# Altium schematic sheet p14_WIFI_SDCARD.SchDoc
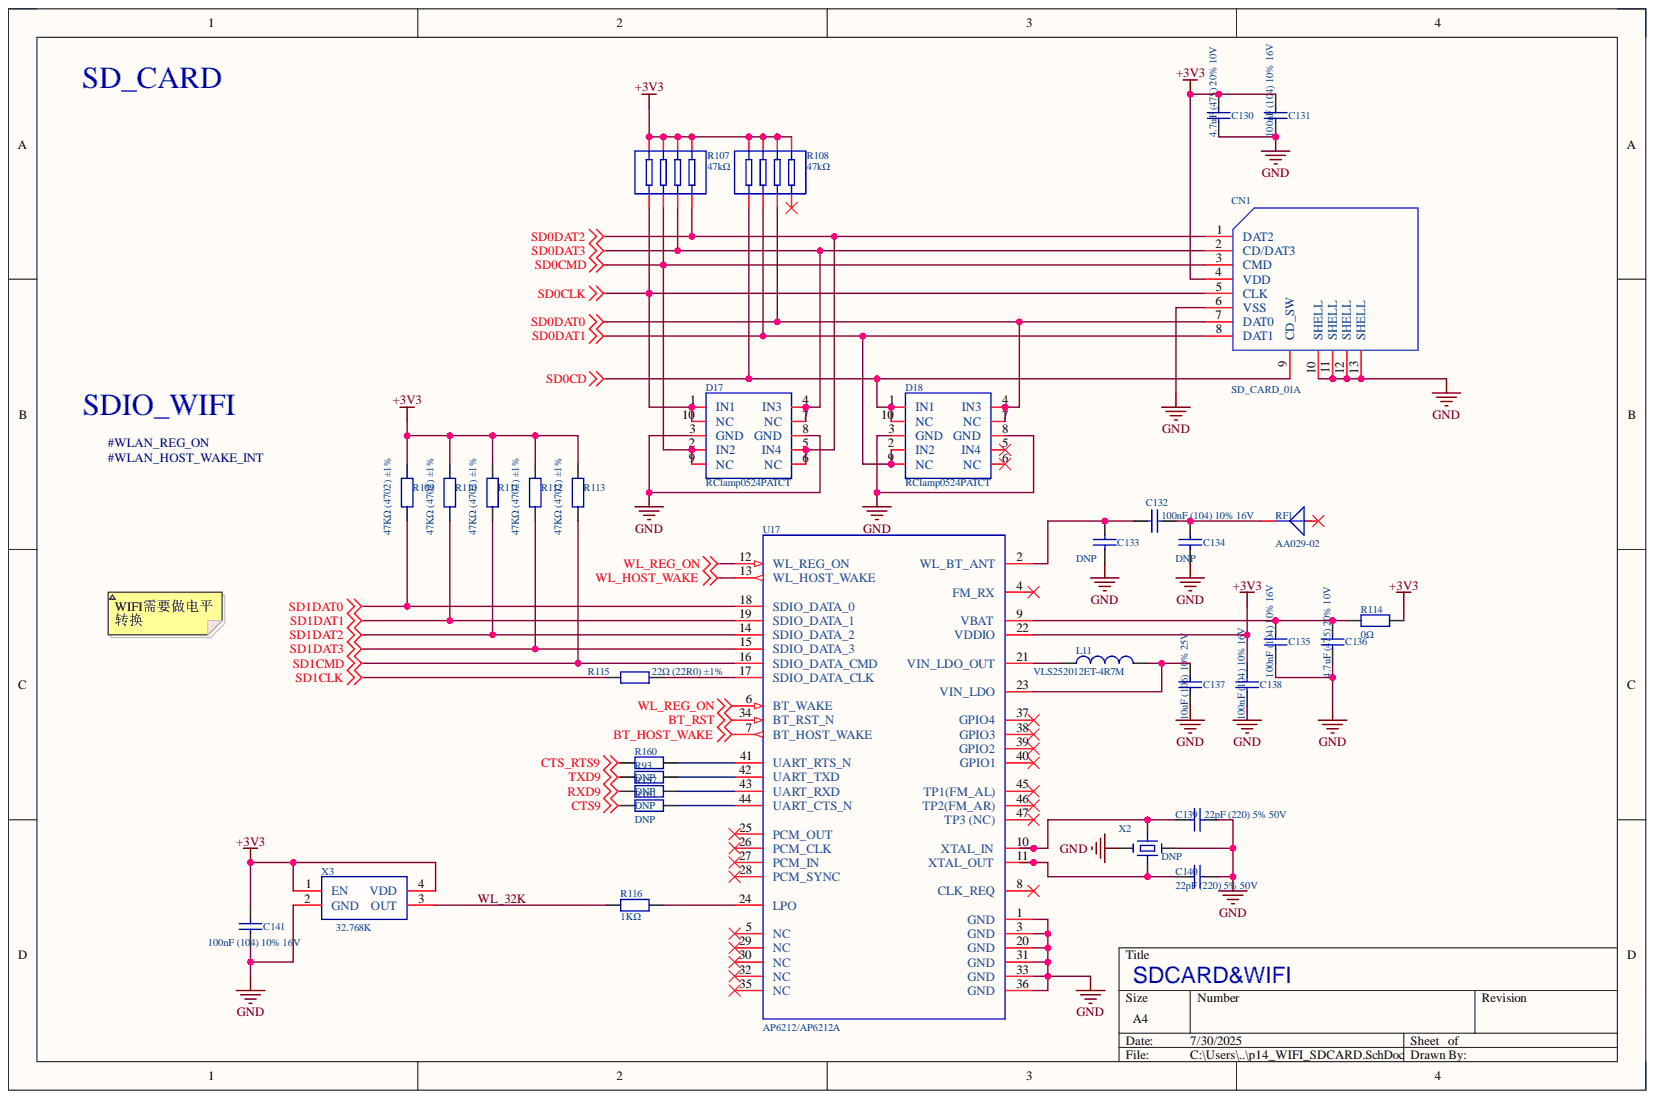
[diagram p0: p14_WIFI_SDCARD.SchDoc]

## Parts
C130  4.7uF (475) 20% 10V  [C0402]
C131  100nF (104) 10% 16V  [C0402]
C132  100nF (104) 10% 16V  [C0402]
C133  DNP  [C0402]
C134  DNP  [C0402]
C135  100nF (104) 10% 16V  [C0402]
C136  4.7uF (475) 20% 10V  [C0402]
C137  10uF (106) 10% 25V  [C0603]
C138  100nF (104) 10% 16V  [C0402]
C139  22pF (220) 5% 50V  [C0402]
C140  22pF (220) 5% 50V  [C0402]
C141  100nF (104) 10% 16V  [0402_C]
CN1  SD_CARD_01A  [SD_Card_TF]
D17  RClamp0524PATCT  [SLP2510P8_L2.5-W1.0-P0.50-BL]
D18  RClamp0524PATCT  [SLP2510P8_L2.5-W1.0-P0.50-BL]
L11  VLS252012ET-4R7M  [VLS252012]
R93  DNP  [SOLDER-BRIDGE_R0402-0R]
R107  47kΩ  [RES-ARRAY-SMD_0402-8P-L2.0-W1.0-BL]
R108  47kΩ  [RES-ARRAY-SMD_0402-8P-L2.0-W1.0-BL]
R109  47KΩ (4702) ±1%  [R0402]
R110  47KΩ (4702) ±1%  [R0402]
R111  47KΩ (4702) ±1%  [R0402]
R112  47KΩ (4702) ±1%  [R0402]
R113  47KΩ (4702) ±1%  [R0402]
R114  0Ω  [R0402]
R115  22Ω (22R0) ±1%  [R0402]
R116  1KΩ  [R0402]
R157  DNP  [SOLDER-BRIDGE_R0402-0R]
R160  DNP  [SOLDER-BRIDGE_R0402-0R]
R161  DNP  [SOLDER-BRIDGE_R0402-0R]
RF1  AA029-02  [ANT-SMD_L5.0-W2.2]
U17  AP6212/AP6212A  [LGA44]
X2  DNP  [SMD_2520]
X3  value 32.768K  [SMD_2520]  (hidden comment: OK3EL89CJI-111YLC-32.768K)

## Nets
+3V3: C130.1 C131.1 C138.2 C141.2 CN1.4(VDD) R107.5 R107.6 R107.7 R107.8 R108.5 R108.6 R108.7 R108.8 R109.2 R110.2 R111.2 R112.2 R113.2 R114.2 U17.22(VDDIO) X3.1(EN) X3.4(VDD)
BT_HOST_WAKE: U17.7(BT_HOST_WAKE)
BT_RST: U17.34(BT_RST_N)
CTS9: R161.1
CTS_RTS9: R160.1
GND: C130.2 C131.2 C133.2 C134.2 C135.1 C136.2 C137.1 C138.1 C139.1 C140.1 C141.1 CN1.6(VSS) CN1.10(SHELL) CN1.11(SHELL) CN1.12(SHELL) CN1.13(SHELL) D17.3(GND) D17.8(GND) D18.3(GND) D18.8(GND) U17.1(GND) U17.3(GND) U17.20(GND) U17.31(GND) U17.33(GND) U17.36(GND) X2.2 X2.4 X3.2(GND)
RXD9: R157.1
SD0CD: CN1.9(CD_SW) D18.1(IN1) D18.10(NC) R108.1
SD0CLK: CN1.5(CLK) D17.1(IN1) D17.10(NC) R107.1
SD0CMD: CN1.3(CMD) D17.2(IN2) D17.9(NC) R107.2
SD0DAT0: CN1.7(DAT0) D18.4(IN3) D18.7(NC) R108.3
SD0DAT1: CN1.8(DAT1) D18.2(IN2) D18.9(NC) R108.2
SD0DAT2: CN1.1(DAT2) D17.5(IN4) D17.6(NC) R107.4
SD0DAT3: CN1.2(CD/DAT3) D17.4(IN3) D17.7(NC) R107.3
SD1CLK: R115.1
SD1CMD: R113.1 U17.16(SDIO_DATA_CMD)
SD1DAT0: R109.1 U17.18(SDIO_DATA_0)
SD1DAT1: R110.1 U17.19(SDIO_DATA_1)
SD1DAT2: R111.1 U17.14(SDIO_DATA_2)
SD1DAT3: R112.1 U17.15(SDIO_DATA_3)
TXD9: R93.1
WL_HOST_WAKE: U17.13(WL_HOST_WAKE)
WL_REG_ON: U17.6(BT_WAKE) U17.12(WL_REG_ON)
WL_32K: R116.1 X3.3(OUT)
NetC132_1: C132.1 C133.1 U17.2(WL_BT_ANT)
NetC132_2: C132.2 C134.1 RF1.1
NetC135_2: C135.2 C136.1 R114.1 U17.9(VBAT)
NetC137_2: C137.2 L11.2 U17.23(VIN_LDO)
NetC139_2: C139.2 U17.10(XTAL_IN) X2.1
NetC140_2: C140.2 U17.11(XTAL_OUT) X2.3
NetL11_1: L11.1 U17.21(VIN_LDO_OUT)
NetR93_2: R93.2 U17.42(UART_TXD)
NetR115_2: R115.2 U17.17(SDIO_DATA_CLK)
NetR116_2: R116.2 U17.24(LPO)
NetR157_2: R157.2 U17.43(UART_RXD)
NetR160_2: R160.2 U17.41(UART_RTS_N)
NetR161_2: R161.2 U17.44(UART_CTS_N)

Not connected: D18 5(IN4) 6(NC); R108 4; RF1 2; U17 4(FM_RX) 5(NC) 8(CLK_REQ) 25(PCM_OUT) 26(PCM_CLK) 27(PCM_IN) 28(PCM_SYNC) 29(NC) 30(NC) 32(NC) 35(NC) 37(GPIO4) 38(GPIO3) 39(GPIO2) 40(GPIO1) 45(TP1(FM_AL)) 46(TP2(FM_AR)) 47(TP3_(NC))

## Library data of the parts
C130 C136 = 0402 4.7uF (475) 20% 10V: 贴片电容; Component Kind: Standard; ComponentLink1Description: 供应商链接; ComponentLink1URL: http://www.szlcsc.com/product/details_24469.html; Library Name: Cap.SchLib; Package: 0402; Supplier: LC; SuppliersPartNumber: C23733
C131 C132 C135 C138 C141 = 0402 100nF (104) 10% 16V: 贴片电容; Component Kind: Standard; ComponentLink1Description: 供应商链接; ComponentLink1URL: http://www.szlcsc.com/product/details_1877.html; Library Name: Cap.SchLib; Package: 0402; Supplier: LC; SuppliersPartNumber: C1525
C133 C134 = 0402 100nF (104) 10% 16V: 贴片电容,100nF (104) 10% 16V; Component Kind: Standard; ComponentLink1Description: 供应商链接; ComponentLink1URL: http://www.szlcsc.com/product/details_1877.html; Library Name: Cap.SchLib; Package: 0402; Supplier: LC; SuppliersPartNumber: C1525
C137 = 0603 10uF (106) 10% 10V: 贴片电容,GRM188R61E106KA73D,0603; Component Kind: Standard; ComponentLink1Description: 供应商链接; ComponentLink1URL: http://www.szlcsc.com/product/details_20411.html; Library Name: Cap.SchLib; Package: 0402; Supplier: LC; SuppliersPartNumber: C19702
C139 C140 = 0402 22pF (220) 5% 50V: 贴片电容，GRM1555C1H220JA01D; Component Kind: Standard; ComponentLink1Description: 供应商链接; ComponentLink1URL: http://www.szlcsc.com/product/details_1907.html; Library Name: Cap.SchLib; Package: 0402; Supplier: LC; SuppliersPartNumber: C1555
CN1 = SD_CARD_01A: 自弹式SD卡插槽，带CD检测; Component Kind: Standard; Library Name: ra8p1_v0.1.SCHLIB
D17 D18 = RClamp0524PATCT: ESD; Manufacturer: SEMTECH; Add into BOM: yes; Breakdown Voltage: 6V; Device: RClamp0524PATCT_C40960; Maximum Clamping Voltage: 15V; Number of Lines: 四路; Peak Pulse Power Dissipation (Ppp): 150W; Reverse Stand-Off Voltage (Vrwm): 5V; Component Kind: Standard; Convert to PCB: yes; Datasheet: https://atta.szlcsc.com/upload/public/pdf/source/20150124/1457707260739.pdf; JLCPCB Part Class: Extended Part; LCSC Part Name: RClamp0524PATCT; Library Name: ra8p1_v0.2.SCHLIB; Manufacturer Part: RClamp0524PATCT; Operating Temperature: -55℃~+125℃@(Tj); PCB3D: SLP2510-8P_L2.5-W1.0-H0.5; Supplier: LCSC; Supplier Footprint: DFN-10(1x2.5); Supplier Part: C40960; Symbol: RClamp0524PATCT; Type: ESD
L11 = Inductor: Code_IEC: 1005; Value: 4.7uH; Code_JEITA: 0402; Component Kind: Standard; Description: Inductor; LatestRevisionDate: 29-May-2009; LatestRevisionNote: IPC-7351 Footprint Added.; Library Name: Miscellaneous Devices.IntLib; PackageDescription: Chip Inductor; PackageReference: 0402-A; Published: 8-Jun-2000; Signal Integrity: Inductor; Simulation: INDUCTOR; Publisher: Altium Limited
R93 R157 R160 R161 = 0402 0Ω (0R0) 1%: 贴片电阻,0Ω; Component Kind: Standard; ComponentLink1Description: 供应商链接; ComponentLink1URL: http://www.szlcsc.com/product/details_17853.html; Library Name: ra8p1_v0.1.SCHLIB; Package: 0402; Supplier: LC; SuppliersPartNumber: C17168
R107 R108 = 4D02WGJ0473TCE: 0402x4; Manufacturer: UNI-ROYAL(厚声); Add into BOM: yes; Number of Resistors: 4; Power(Watts): 62.5mW; Temperature Coefficient: ±200ppm/℃; Tolerance: ±5%; Component Kind: Standard; Convert to PCB: yes; Datasheet: https://atta.szlcsc.com/upload/public/pdf/source/20191224/C474318_2527498AE30E813171187CCD28401A71.pdf; Device: 4D02WGJ0473TCE; JLCPCB Part Class: Extended Part; LCSC Part Name: 47kΩ ±5%; Library Name: ra8p1_v0.2.SCHLIB; Manufacturer Part: 4D02WGJ0473TCE; Number of Pins: 8; PCB3D: R0402-8P_L2.0-W1.0-H0.5; Supplier: LCSC; Supplier Footprint: 0402x4; Supplier Part: C2028; Symbol: 4D02WGJ0473TCE; Value: 47kΩ
R109-R113 = 0402 47KΩ (4702) 1%: 贴片电阻; Component Kind: Standard; ComponentLink1Description: 供应商链接; ComponentLink1URL: http://www.szlcsc.com/product/details_26535.html; Library Name: RES.SchLib; Package: 0402; Supplier: LC; SuppliersPartNumber: C25792
R114 = 0402 0Ω (0R0) 1%: 贴片电阻; Component Kind: Standard; ComponentLink1Description: 供应商链接; ComponentLink1URL: http://www.szlcsc.com/product/details_17853.html; Library Name: RES.SchLib; Package: 0402; Supplier: LC; SuppliersPartNumber: C17168
R115 = 0402 22Ω (22R0) 1%: 贴片电阻; Component Kind: Standard; ComponentLink1Description: 供应商链接; ComponentLink1URL: http://www.szlcsc.com/product/details_25835.html; Library Name: RES.SchLib; Package: 0402; Supplier: LC; SuppliersPartNumber: C25092
R116 = 0402 1KΩ (1001) 1%: 贴片电阻; Component Kind: Standard; ComponentLink1Description: 供应商链接; ComponentLink1URL: http://www.szlcsc.com/product/details_12256.html; Library Name: RES.SchLib; Package: 0402; Supplier: LC; SuppliersPartNumber: C11702
RF1 = AA029-02: Manufacturer: LXC(连兴创); Add into BOM: yes; Component Kind: Standard; Convert to PCB: yes; Datasheet: https://atta.szlcsc.com/upload/public/pdf/source/20241122/4B588DFEF84F3595867722A3E2DF5B0F.pdf; Device: AA029-02; JLCPCB Part Class: Extended Part; LCSC Part Name: LXC(连兴创)陶瓷SMT天线-AA029; Library Name: ra8p1_v0.2.SCHLIB; Manufacturer Part: AA029-02; PCB3D: ANT-SMD_L5.0-W2.2; Supplier: LCSC; Supplier Footprint: -; Supplier Part: C42379504; Symbol: AA029-02
U17 = AP6212A: Component Kind: Standard; Library Name: ra8p1_v0.1.SCHLIB
X2 = Crystal: OT252026MJBA4SL，26M; VALUEH: 26M; Component Kind: Standard; ComponentLink1Description: New link; ComponentLink1URL: https://item.szlcsc.com/71684.html?fromZone=l_b__%2522brand%2522&spm=sc.bid.xh2.zy.n&lcsc_vid=FANcVFBQFQMNX1cFFAdZVVZXEgdeAgdWFlQNUVAHT1ExVlNSQ1FZUlJfQldYVDsOAxUeFF5JWA0HFBBIBBsCBBcFWQIBCksEBQAAAzsWFgYLSFZeQE8GEwkK; Library Name: ART-H7_LIB.SchLib
X3 = Cystal_OSC32: Component Kind: Standard; ComponentLink1Description: New link; ComponentLink1URL: https://item.szlcsc.com/8414366.html?fromZone=l_b__%2522brand%2522&spm=sc.bid.xh4.zy.n&lcsc_vid=FANcVFBQFQMNX1cFFAdZVVZXEgdeAgdWFlQNUVAHT1ExVlNSRFhXUFZTQ1RWUzsOAxUeFF5JWA0HFBBIBBsCBBcFWQIBCksEBQAAAzsWFgYLSFZeQE8GEwkK; Description: OK3EL89CJI-111YLC-32.768K; Library Name: ra8p1_v0.1.SCHLIB
